# Altium schematic sheet Beechat_SCH_REV1.1.SchDoc
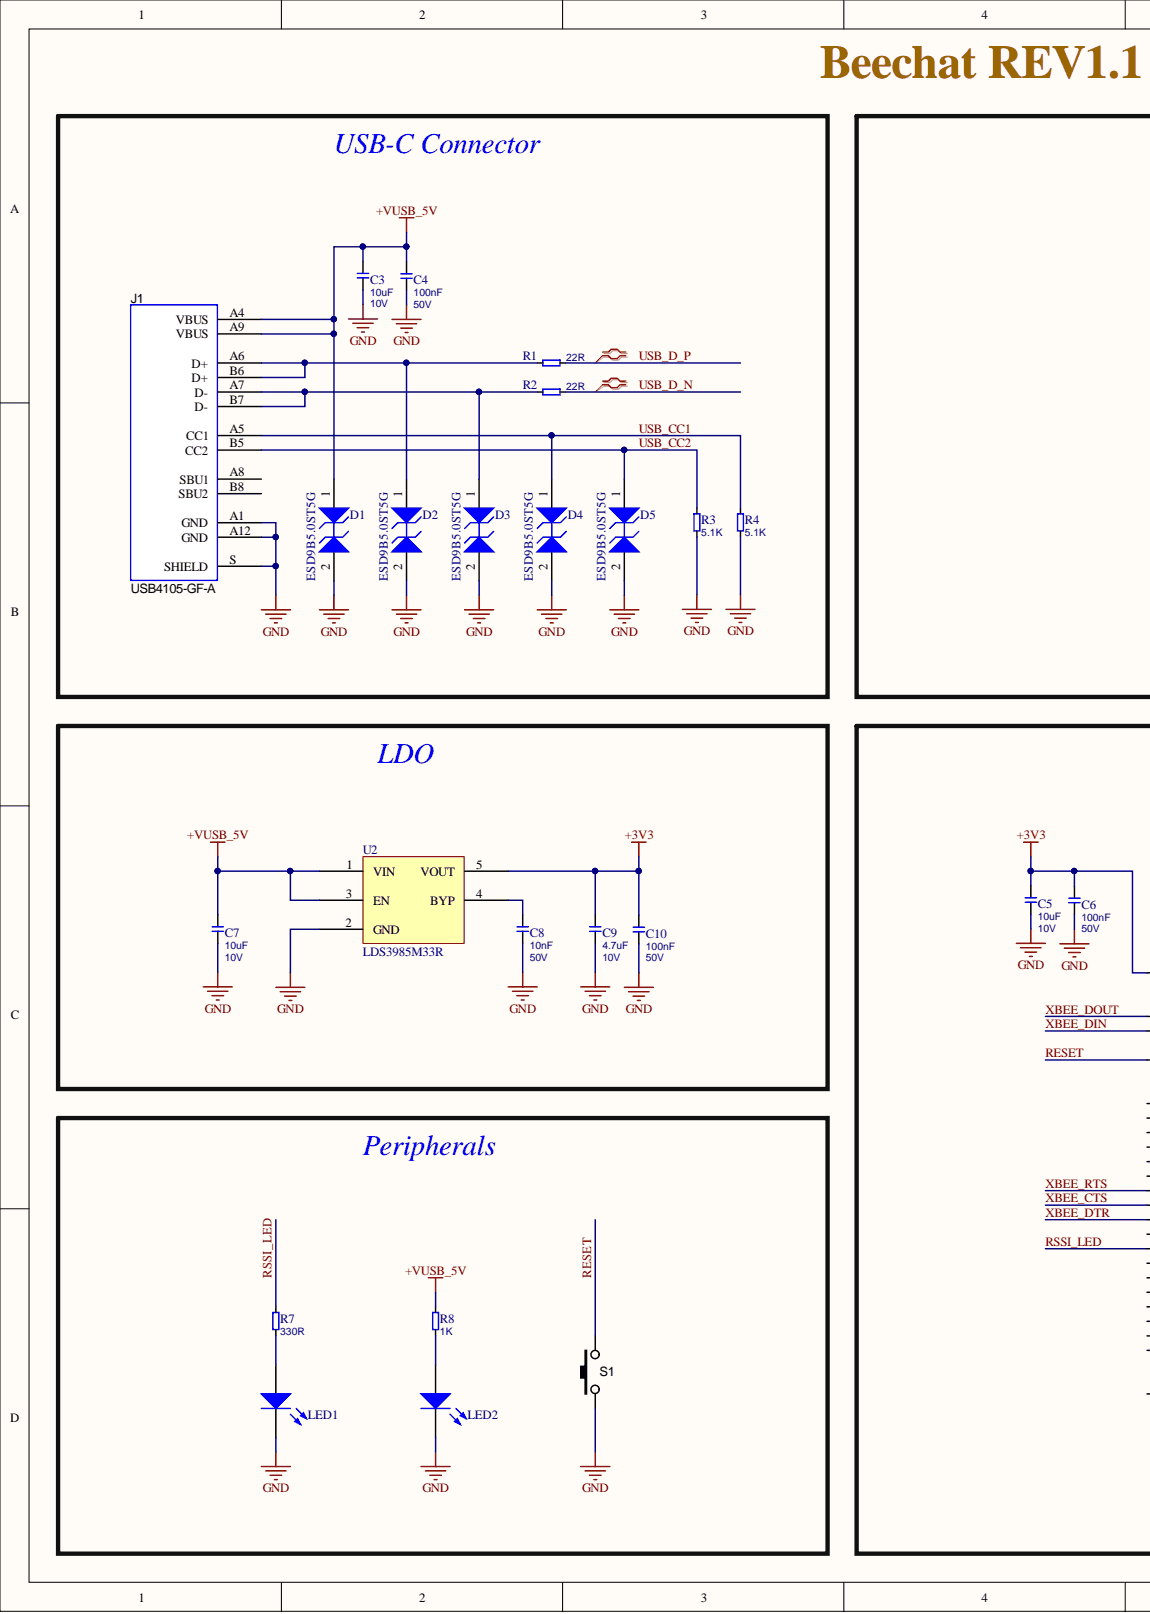
[diagram p0: Beechat_SCH_REV1.1.SchDoc, left]
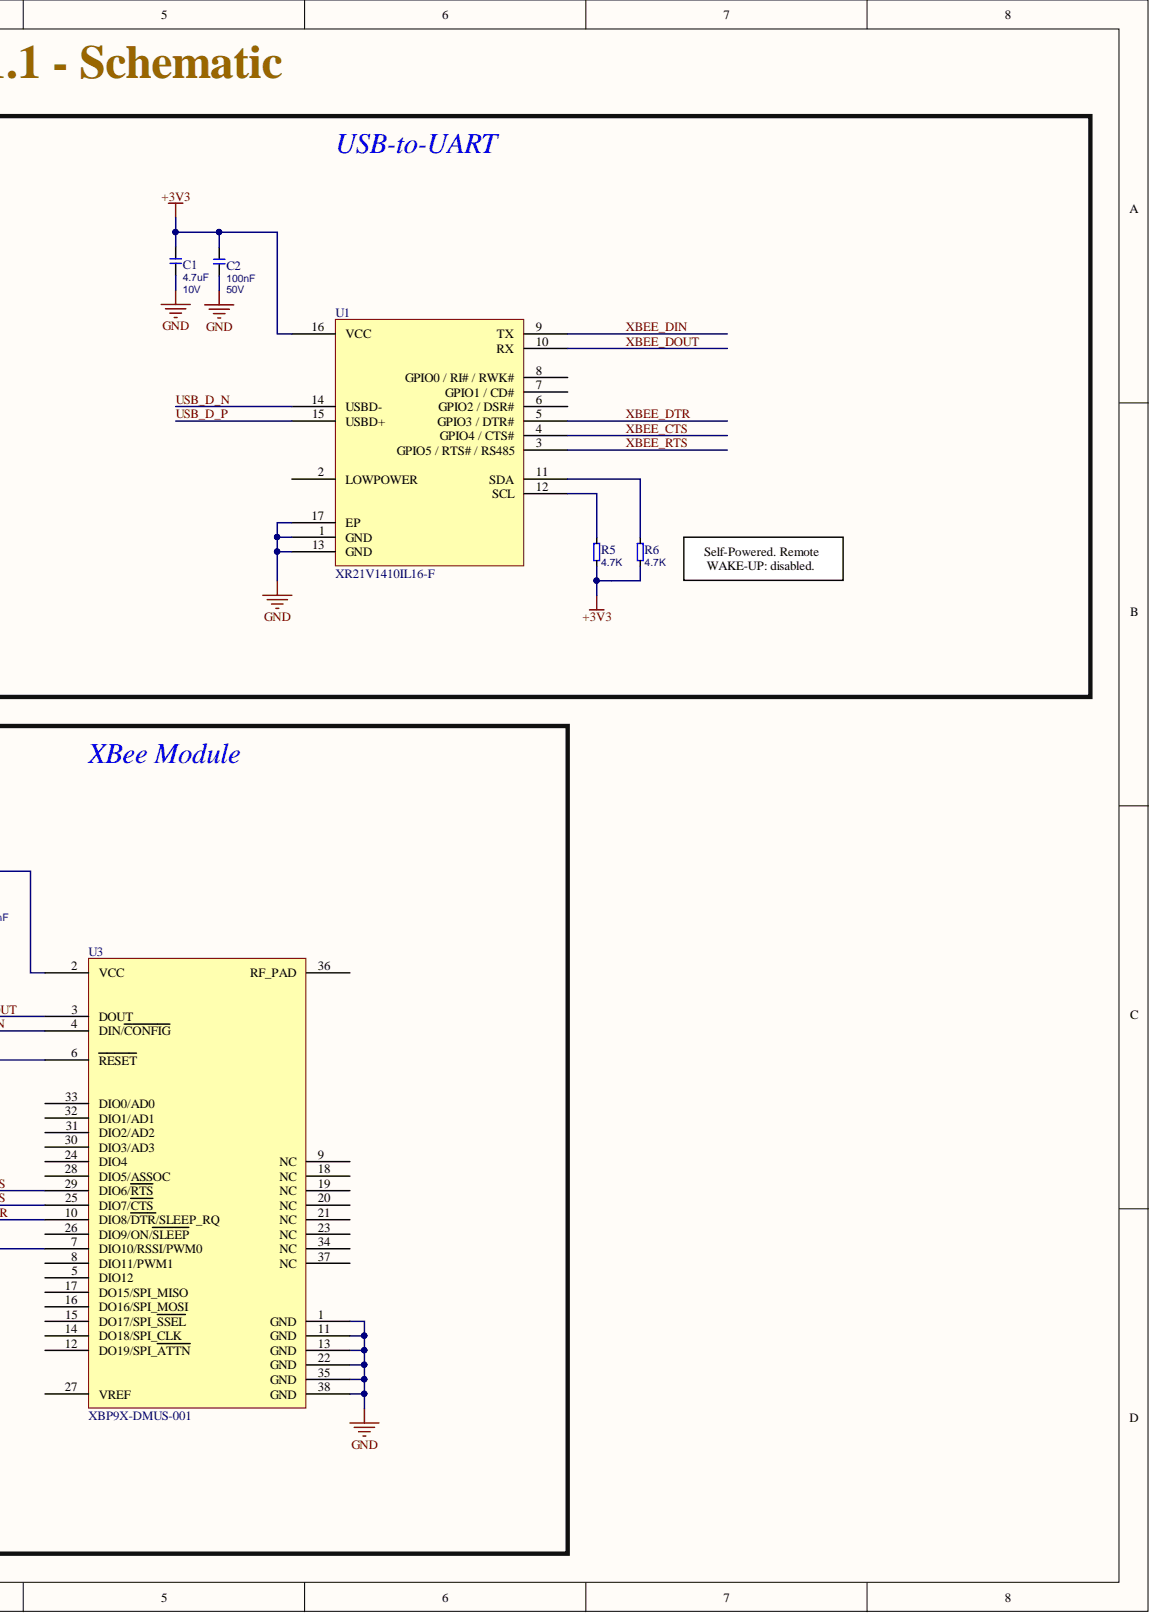
[diagram p1: Beechat_SCH_REV1.1.SchDoc, right]

## Parts
C1  4.7uF  Rating: 10V  [CAP0603]
C2  100nF  Rating: 50V  [CAP0402]
C3  10uF  Rating: 10V  [CAP0603]
C4  100nF  Rating: 50V  [CAP0402]
C5  10uF  Rating: 10V  [CAP0603]
C6  100nF  Rating: 50V  [CAP0402]
C7  10uF  Rating: 10V  [CAP0603]
C8  10nF  Rating: 50V  [CAP0402]
C9  4.7uF  Rating: 10V  [CAP0603]
C10  100nF  Rating: 50V  [CAP0402]
D1  ESD9B5.0ST5G  [SOD-923]
D2  ESD9B5.0ST5G  [SOD-923]
D3  ESD9B5.0ST5G  [SOD-923]
D4  ESD9B5.0ST5G  [SOD-923]
D5  ESD9B5.0ST5G  [SOD-923]
J1  USB4105-GF-A  [USB4105-GF-A]
LED1  LED_0402  [Led-0402]  (value not drawn)
LED2  LED_0402  [Led-0402]  (value not drawn)
R1  22R  [RES0402]
R2  22R  [RES0402]
R3  5.1K  [RES0402]
R4  5.1K  [RES0402]
R5  4.7K  [RES0402]
R6  4.7K  [RES0402]
R7  330R  [RES0402]
R8  1K  [RES0402]
S1  8-1437565-1  [8-1437565-1]  (value not drawn)
U1  XR21V1410IL16-F  [QFN-16]
U2  LDS3985M33R  [SOT23-5]
U3  XBP9X-DMUS-001  [XB8X-DMUS-001]

## Nets
+3V3: C1.2 C2.2 C5.2 C6.2 C9.2 C10.2 R5.1 R6.1 U1.16(VCC) U2.5(VOUT) U3.2(VCC)
+VUSB_5V: C3.2 C4.2 C7.2 D1.1 J1.A4(VBUS) J1.A9(VBUS) R8.2 U2.1(VIN) U2.3(EN)
GND: C1.1 C2.1 C3.1 C4.1 C5.1 C6.1 C7.1 C8.1 C9.1 C10.1 D1.2 D2.2 D3.2 D4.2 D5.2 J1.A1(GND) J1.A12(GND) J1.S(SHIELD) LED1.2 LED2.2 R3.1 R4.1 S1.1 U1.1(GND) U1.13(GND) U1.17(EP) U2.2(GND) U3.1(GND) U3.11(GND) U3.13(GND) U3.22(GND) U3.35(GND) U3.38(GND)
RESET: S1.2 U3.6(RESET)
RSSI_LED: R7.2 U3.7(DIO10/RSSI/PWM0)
USB_CC1: D4.1 J1.A5(CC1) R4.2
USB_CC2: D5.1 J1.B5(CC2) R3.2
USB_D_N: R2.2 U1.14(USBD-)
USB_D_P: R1.2 U1.15(USBD+)
XBEE_CTS: U1.4(GPIO4_/_CTS#) U3.25(DIO7/CTS)
XBEE_DIN: U1.9(TX) U3.4(DIN/CONFIG)
XBEE_DOUT: U1.10(RX) U3.3(DOUT)
XBEE_DTR: U1.5(GPIO3_/_DTR#) U3.10(DIO8/DTR/SLEEP_RQ)
XBEE_RTS: U1.3(GPIO5_/_RTS#_/_RS485) U3.29(DIO6/RTS)
NetC8_2: C8.2 U2.4(BYP)
NetD2_1: D2.1 J1.A6(D+) J1.B6(D+) R1.1
NetD3_1: D3.1 J1.A7(D-) J1.B7(D-) R2.1
NetLED1_1: LED1.1 R7.1
NetLED2_1: LED2.1 R8.1
NetR5_2: R5.2 U1.12(SCL)
NetR6_2: R6.2 U1.11(SDA)

Not connected: J1 A8(SBU1) B8(SBU2); U1 2(LOWPOWER) 6(GPIO2_/_DSR#) 7(GPIO1_/_CD#) 8(GPIO0_/_RI#_/_RWK#); U3 5(DIO12) 8(DIO11/PWM1) 9(NC) 12(DO19/SPI_ATTN) 14(DO18/SPI_CLK) 15(DO17/SPI_SSEL) 16(DO16/SPI_MOSI) 17(DO15/SPI_MISO) 18(NC) 19(NC) 20(NC) 21(NC) 23(NC) 24(DIO4) 26(DIO9/ON/SLEEP) 27(VREF) 28(DIO5/ASSOC) 30(DIO3/AD3) 31(DIO2/AD2) 32(DIO1/AD1) 33(DIO0/AD0) 34(NC) 36(RF_PAD) 37(NC)

## Library data of the parts
C1 C3 C5 C7 C9 = CAP_0603: Capacitor Ceramic 0603; Tolerance: 10%; Component Kind: Standard; Library Name: Lamp_LIB.SchLib
  C1 C9: Value: 4.7uF
  C3 C5 C7: Value: 10uF
C2 C4 C6 C8 C10 = CAP_0402: Capacitor Ceramic 0402; Tolerance: 10%; Component Kind: Standard; Library Name: Lamp_LIB.SchLib
  C2 C4 C6 C10: Value: 100nF
  C8: Value: 10nF
D1-D5 = ESD9B5.0ST5G: TVS DIODE 5V SOD923; Component Kind: Standard; Library Name: Lamp_LIB.SchLib
J1 = USB4105-GF-A: USB SHIELDED I/O RECP TYPE C; Component Kind: Standard; Library Name: Lamp_LIB.SchLib
LED1 LED2 = LED_0402: SMD LED; Component Kind: Standard; Library Name: Lamp_LIB.SchLib
R1-R8 = R_0402: Resistor SMD 0402; Tolerance: 1%; Component Kind: Standard; Library Name: Lamp_LIB.SchLib
  R1 R2: Value: 22R
  R3 R4: Value: 5.1K
  R5 R6: Value: 4.7K
  R7: Value: 330R
  R8: Value: 1K
S1 = 8-1437565-1: SWITCH TACTILE SPST-NO 0.02A 15V; Component Kind: Standard; Library Name: Lamp_LIB.SchLib
U1 = XR21V1410IL16-F: IC UART FIFO USB SGL 16QFN; Component Kind: Standard; Library Name: Lamp_LIB.SchLib
U2 = LDS3985M33R: IC REG LINEAR 3.3V 300MA SOT23-5; Component Kind: Standard; Library Name: Lamp_LIB.SchLib
U3 = XBP9X-DMUS-001: RX TXRX MOD ISM < 1GHZ U.FL SMD; Component Kind: Standard; Library Name: Lamp_LIB.SchLib
